# Altium schematic sheet Peripherals.SchDoc
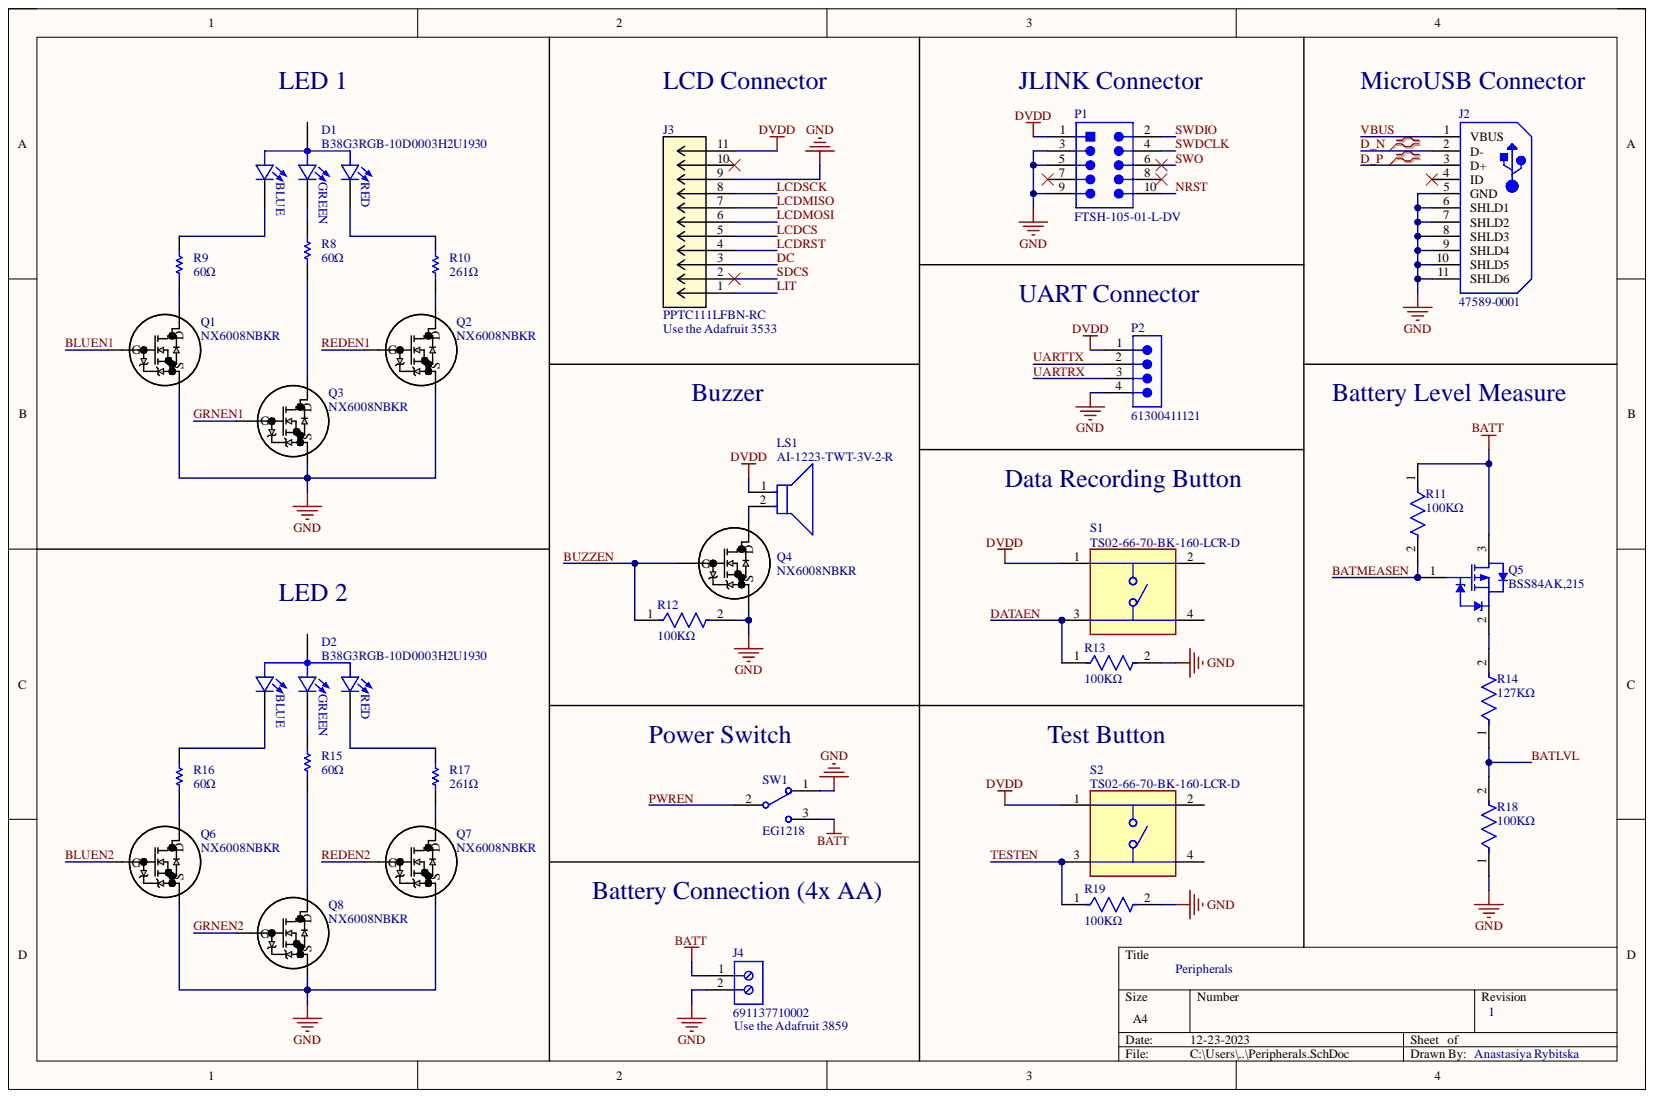
[diagram p0: Peripherals.SchDoc]

## Parts
D1  B38G3RGB-10D0003H2U1930  [LED_B38G3RGB-10D0003H2U1930]
D2  B38G3RGB-10D0003H2U1930  [LED_B38G3RGB-10D0003H2U1930]
J2  47589-0001  [MOLX-47589-0001_V]
J3  PPTC111LFBN-RC  [CONN11_PPPN111_SUL]
J4  691137710002  [691137710002]
LS1  AI-1223-TWT-3V-2-R  [FP-AI-1223-TWT-3V-2-R-MFG]
P1  FTSH-105-01-L-DV  [SMTC-FTSH-105-01-L-DV_V]
P2  61300411121  [61300411121]
Q1  NX6008NBKR  [NX6008NBKR_NEX]
Q2  NX6008NBKR  [NX6008NBKR_NEX]
Q3  NX6008NBKR  [NX6008NBKR_NEX]
Q4  NX6008NBKR  [NX6008NBKR_NEX]
Q5  BSS84AK,215  [NXP-SOT23_N]
Q6  NX6008NBKR  [NX6008NBKR_NEX]
Q7  NX6008NBKR  [NX6008NBKR_NEX]
Q8  NX6008NBKR  [NX6008NBKR_NEX]
R8  Resistance: 60Ω  [RESC1608X55X25LL10T15]  (hidden comment: RC0603JR-0762RL)
R9  Resistance: 60Ω  [RESC1608X55X25LL10T15]  (hidden comment: RC0603JR-0762RL)
R10  Resistance: 261Ω  [RESC1608X55X25LL10T15]  (hidden comment: RC0603FR-07261RL)
R11  Resistance: 100KΩ  [FP-RMCF0603-IPC_C]  (hidden comment: RMCF0603FT100K)
R12  Resistance: 100KΩ  [FP-RMCF0603-IPC_C]  (hidden comment: RMCF0603FT100K)
R13  Resistance: 100KΩ  [FP-RMCF0603-IPC_C]  (hidden comment: RMCF0603FT100K)
R14  value 127KΩ  Resistance: 127KΩ  [FP-RT0603-MFG]  (hidden comment: RT0603FRE07127KL)
R15  Resistance: 60Ω  [RESC1608X55X25LL10T15]  (hidden comment: RC0603JR-0762RL)
R16  Resistance: 60Ω  [RESC1608X55X25LL10T15]  (hidden comment: RC0603JR-0762RL)
R17  Resistance: 261Ω  [RESC1608X55X25LL10T15]  (hidden comment: RC0603FR-07261RL)
R18  Resistance: 100KΩ  [FP-RMCF0603-IPC_C]  (hidden comment: RMCF0603FT100K)
R19  Resistance: 100KΩ  [FP-RMCF0603-IPC_C]  (hidden comment: RMCF0603FT100K)
S1  TS02-66-70-BK-160-LCR-D  [SW_TS02-66-70-BK-160-LCR-D]
S2  TS02-66-70-BK-160-LCR-D  [SW_TS02-66-70-BK-160-LCR-D]
SW1  EG1218  [ESWI-EG1218_V]

## Nets
BATT: J4.1 Q5.3 R11.1 SW1.3
DVDD: J3.11 LS1.1 P1.1 P2.1 S1.1 S2.1
GND: J2.5(GND) J2.6(SHLD1) J2.7(SHLD2) J2.8(SHLD3) J2.9(SHLD4) J2.10(SHLD5) J2.11(SHLD6) J3.9 J4.2 P1.3 P1.5 P1.9 P2.4 Q1.2 Q2.2 Q3.2 Q4.2 Q6.2 Q7.2 Q8.2 R12.2 R13.2 R18.1 R19.2 SW1.1
BATLVL: R14.1 R18.2
BATMEASEN: Q5.1 R11.2
BLUEN1: Q1.1
BLUEN2: Q6.1
BUZZEN: Q4.1 R12.1
D_N: J2.2(D-)
D_P: J2.3(D+)
DATAEN: R13.1 S1.3
DC: J3.3
GRNEN1: Q3.1
GRNEN2: Q8.1
LCDCS: J3.5
LCDMISO: J3.7
LCDMOSI: J3.6
LCDRST: J3.4
LCDSCK: J3.8
LIT: J3.1
NRST: P1.10
PWREN: SW1.2
REDEN1: Q2.1
REDEN2: Q7.1
SDCS: J3.2
SWDCLK: P1.4
SWDIO: P1.2
SWO: P1.6
TESTEN: R19.1 S2.3
UARTRX: P2.3
UARTTX: P2.2
VBUS: J2.1(VBUS)
NetD1_1: D1.1 R8.2
NetD1_2: D1.2 R9.2
NetD1_4: D1.4 R10.2
NetD2_1: D2.1 R15.2
NetD2_2: D2.2 R16.2
NetD2_4: D2.4 R17.2
NetLS1_2: LS1.2 Q4.3
NetQ1_3: Q1.3 R9.1
NetQ2_3: Q2.3 R10.1
NetQ3_3: Q3.3 R8.1
NetQ5_2: Q5.2 R14.2
NetQ6_3: Q6.3 R16.1
NetQ7_3: Q7.3 R17.1
NetQ8_3: Q8.3 R15.1

Not connected: D1 3; D2 3; J2 4(ID); J3 10; P1 7 8; S1 2 4; S2 2 4

## Library data of the parts
D1 D2 = B38G3RGB-10D0003H2U1930: Red, Green, Blue (RGB) - LED Indication - Discrete 2V Red, 3V Green, 3V Blue 0606 (1616 Metric); MF: Harvatek Corporation; Availability: In Stock; Check_prices: https://www.snapeda.com/parts/B38G3RGB-10D0003H2U1930/Harvatek/view-part/?ref=eda; Component Kind: Standard; Library Name: Schlib1.SchLib; MP: B38G3RGB-10D0003H2U1930; Package: None; Price: None; Purchase-URL: https://pricing.snapeda.com/search/part/B38G3RGB-10D0003H2U1930/?ref=eda; SnapEDA_Link: https://www.snapeda.com/parts/B38G3RGB-10D0003H2U1930/Harvatek/view-part/?ref=snap
J2 = CMP-2000-05610-1: Right Angle Female Type AB Micro USB Connector, 30 V, 1.8 A, -30 to 85 degC, RoHS, Tape and Reel; Brand: Molex; Connector Type: MicroUSBTypeABreceptacle; Current Rating: 1.8A; Number of Contacts: 5Contact; Number of Ports: 1Port; Voltage Rating: 30VAC; Color: Black; Component Kind: Standard; Contact Material: CopperAlloy; Contact Plating: Gold; Datasheet URL: http://www.molex.com/webdocs/datasheets/pdf/en-us/0475890001_IO_CONNECTORS.pdf; Datasheet Version: 12/2016; Gender: Female; Library Name: Altium Content Vault; Manufacturer: Molex; Manufacturer URL: http://www.molex.com/; Mounting Angle: Right; Mounting Technology: SurfaceMount; Operating Temperature Range: -20Cto+85C; Package Description: Micro USB Connector, Pitch 0.65 mm, 5 Positions, Body 7.5 x 5.6 mm; Package Reference: 47589-0001; Package URL: http://www.molex.com/pdm_docs/sd/475890001_sd.pdf; Packaging: Reel; Product: MicroUSBTypeABConnectors; Product Category: USBConnectors; RoHS: True; Series: 47589; Standard: USB; Termination Style: SMD/SMT
J3 = PPTC111LFBN-RC: No Description Available; Mfr_Name: Sullins; Component Kind: Standard; Library Name: Schlib1.SchLib; Manufacturer_Part_Number: PPTC111LFBN-RC; RefDes: RefDes; Type: DEV; Copyright: Copyright (C) 2023 Ultra Librarian. All rights reserved.
J4 = CMP-1502-03324-2: NexarPartId: 78871135; Current Rating: 16A; Number of Contacts: 2; Voltage Rating: 300V; Component Kind: Standard; Contact Material: Nickel, Tin; Height: 11.6mm; Lead Pitch: 5mm; Library Name: Altium Content Vault; Max Operating Temperature: 120°C; Min Operating Temperature: -30°C; Number of Pins: 2; Number of Rows: 1; Packaging: Box; RoHS: Non-Compliant
LS1 = CMP-103888-000003-1: BUZZER MAGNETIC 3V 12MM TH; Frequency: 2.3kHz; Input Type: DC; Max Supply Current: 30mA; Max Supply Voltage (DC): 4V; Min Supply Voltage (DC): 2V; Component Kind: Standard; Contact Plating: Tin; Diameter: 12mm; Height: 7.5mm; Library Name: Altium Content Vault; Max Operating Temperature: 70°C; Min Operating Temperature: -20°C; Mount: Through Hole; Nominal Supply Voltage (DC): 3V; Operating Supply Current: 30mA; Packaging: Tray; Sensing Method: Magnetic; Series: AI; Termination: PC Pins; Voltage Rating: 3V
P1 = CMP-2000-05354-1: CONN HEADER SMD 10POS 1.27MM (get from Garmin, or order cheaper); Manufacturer: Samtec; Catalog Drawings: FTSHSeriesFTSHSeriesSMTSide; Connector Type: Header,Unshrouded; EDA / CAD Models: DownloadfromAcceleratedDesigns; Featured Product: Board-To-BoardInterconnectSystems; Mating Products: FLE-105-01-G-DV-TR-ND-CONNSKT1.27MM10POSDUALSMDCLP-105-02-G-D-P-TR-ND-CONNRCPT10POSDUAL.05"SMDSFMC-105-L2-S-D-TR-ND-CONN.05"SURFACE10POSSMTSFMH-105-02-L-D-TR-ND-CONNRECEPT10POS.50"SMTFLE-105-01-G-DV-A-ND-CONNSKT1.27MM10POSDUALSMDCLP-105-02-G-D-BE-ND-CONNRCPT10POSDUAL.05"SMDFLE-105-01-G-DV-A-P-ND-CONNSKT1.27MM10POSDUALSMDSAM1153-05-ND-CONNRCPT10POSDUAL.05"SMDSAM1155-05-ND-CONNRCPT10POSDUAL.05"SMDSAM1154-05-ND-CONNRCPT10POSDUAL.05"SMDSFMH-105-02-L-D-LC-ND-CONNRECEPT10POS.50"SMTSAM1226-05-ND-CONNRCPT.05"10POSDUALSMD; Number of Positions: 10; Number of Positions Loaded: All; Online Catalog: FTSHSeries; Other Names: SAM1161-05; Pitch: 0.050"(1.27mm); Product Training Modules: Board-to-BoardConnectors; Category: Connectors,Interconnects; Color: Black; Component Kind: Standard; Contact Finish: Gold; Contact Finish Thickness: 10µin(0.25µm); Contact Mating Length: 0.120"(3.05mm); Contact Type: MalePin; Datasheet URL: https://datasheet.ciiva.com/30493/0900766b80adfe36-30493006.pdf; Datasheet Version: Feb-08; Family: RectangularConnectors-Headers,MalePins; Fastening Type: -; Features: -; Library Name: Altium Content Vault; Manufacturer URL: http://www.samtec.com/; Mounting Type: SurfaceMount; Number of Rows: 2; Packaging: Bulk; Row Spacing: 0.050"(1.27mm); Series: FTSH; Standard Package: 1; Termination: Solder
P2 = CMP-1502-01064-2: NexarPartId: 32855522; Connector Type: Male Pin; Contact Resistance: 20mΩ; Current Rating: 3A; Gender: Male; Number of Contacts: 4; Operating Supply Voltage: 250V; Pitch: 2.54mm; Color: Black; Component Kind: Standard; Contact Material: Copper; Contact Mating Length: 5.9944mm; Contact Plating: Gold; Height: 8.89mm; Lead Free: Lead Free; Lead Pitch: 2.54mm; Library Name: Altium Content Vault; Max Operating Temperature: 105°C; Min Operating Temperature: -40°C; Mount: Through Hole; Number of Pins: 4; Number of Positions: 4; Number of Rows: 1; Orientation: Vertical; Packaging: Bulk; REACH SVHC: Unknown; RoHS: Compliant; Termination: Solder; Voltage Rating: 250V
Q1-Q4 Q6-Q8 = NX6008NBKR: No Description Available; Mfr_Name: Nexperia; Type: DEV; Component Kind: Standard; Library Name: Schlib1.SchLib; Manufacturer_Part_Number: NX6008NBKR; RefDes: RefDes; Copyright: Copyright (C) 2023 Ultra Librarian. All rights reserved.
Q5 = CMP-1259-00086-1: P-Channel Trench MOSFET, -50 V, -0.18 A, -55 to 150 degC, 3-Pin SOT23, Tape and Reel; Manufacturer: NXP Semiconductors; Automotive Qualified: Y; Channel Type: P; IDM [max] (A): -0.7; Packing: Tape & Reel; VGSth [typ] (V): -1.6; Code_JEDEC: TO-236AB; Component Kind: Standard; ComponentLink1Description: Manufacturer URL; ComponentLink1URL: http://www.nxp.com/; ComponentLink2Description: Datasheet; ComponentLink2URL: http://www.nxp.com/documents/data_sheet/BSS84AK.pdf; ComponentLink3Description: Package Specification; ComponentLink3URL: http://www.nxp.com/documents/outline_drawing/sot023_po.pdf; DatasheetVersion: Rev. 1; ID [max] (A): -0.18; Library Name: Altium Content Vault; Mounting Technology: Surface Mount; PackageDescription: 3-Pin Small Outline Transistor Package, Body 2.9 x 1.3 mm, Pitch 0.95 mm; PackageReference: SOT23; PackageVersion: 06-03-16, 03/2006; PartNumber: BSS84AK,215; VDS [max] (V): -50
R8 R9 R15 R16 = CMP-2000-04286-1: Manufacturer: Yageo; Component Kind: Standard; Library Name: Altium Content Vault; Part Number: RC0603JR-0762RL
R10 R17 = CMP-2000-03972-1: Manufacturer: Yageo; Component Kind: Standard; Library Name: Altium Content Vault; Part Number: RC0603FR-07261RL
R11-R13 R18 R19 = CMP-2000-06989-2: 100kΩ ±1% 0.1W 0603 Thick Film Chip Resistor AEC-Q200 compliant; Max Power Dissipation: 100mW; Temperature Coefficient: 100ppm/°C; Working Voltage: 75V; Case Code (Imperial): 0603; Case Code (Metric): 1608; Case/Package: 0603; Component Kind: Standard; Construction: Rectangular; Contact Plating: Tin; Depth: 0.8mm; Features: Automotive AEC-Q200; Height: 0.022inch; Lead Free: Lead Free; Length: 1.55mm; Library Name: Altium Content Vault; Max Operating Temperature: 155°C; Military Standard: Not; Min Operating Temperature: -55°C; Mount: Surface Mount; Number of Terminations: 2; Packaging: Tape and Reel; Power Rating: 100mW; Radiation Hardening: No; Resistor Type: Thick Film; RoHS Compliant: Yes; Series: RMCF; Tolerance: 1%; Width: 0.031inch
R14 = CMP-03412-001424-1: RES SMD 127K OHM 1% 1/10W 0603; Manufacturer: Yageo; Power Rating: 0.1W; Temperature Coefficient: 50 ppm/°C; Tolerance: 0.01; Voltage Rating: 75V; Automotive: No; Category: Res; Component Kind: Standard; ComponentLink2Description: Datasheet; ComponentLink2URL: http://www.yageo.com/documents/recent/PYu-RT_1-to-0.01_RoHS_L_9.pdf; Composition: Thin Film; Device Class L1: Passive Components; Device Class L2: Resistors; Device Class L3: Chip SMD Resistors; Digikey Description: RES SMD 127K OHM 1% 1/10W 0603; Footprint Url: http://www.yageo.com/exep/pages/download/literatures/PYu-R_Mount_10.pdf; Height: 0.55mm; Ipc Land Pattern Name: RESC16080X45; Lead Free: Yes; Library Name: Altium Content Vault; Manufacturer Part Number: RT0603FRE07127KL; Mouser Description: Thin Film Resistors - SMD 1/10W 127K ohm 1% 50ppm; Octopart: https://octopart.com/rt0603fre07127kl-yageo-1402897; Package: RT0603; RoHS: TRUE; Series: RT; Temperature: -55°C to +155°C; Temperature Range High: +155°C; Temperature Range Low: -55°C
S1 S2 = TS02-66-70-BK-160-LCR-D: 6 x 6 mm, 7 mm Actuator Height, 160 gf, Black, Long Crimped, Through Hole, SPST, Tactile Switch; MF: CUI Devices; Availability: In Stock; Check_prices: https://www.snapeda.com/parts/TS02-66-70-BK-160-LCR-D/CUI+Devices/view-part/?ref=eda; Component Kind: Standard; CUI_purchase_URL: https://www.cuidevices.com/product/switches/tactile-switches/ts02-series?utm_source=snapeda.com&utm_medium=referral&utm_campaign=snapedaBOM; Library Name: Schlib1.SchLib; MP: TS02-66-70-BK-160-LCR-D; Package: None; Price: None; Purchase-URL: https://pricing.snapeda.com/search/part/TS02-66-70-BK-160-LCR-D/?ref=eda; SnapEDA_Link: https://www.snapeda.com/parts/TS02-66-70-BK-160-LCR-D/CUI+Devices/view-part/?ref=snap
SW1 = CMP-1696-00002-1: Slide Switch, 500 V, -20 to 70 degC, 3-Pin THD, RoHS; Case\Package: SOT-23-5; Dropout Voltage: 300 mV; Max Input Voltage: 8 V; Max Ouput Current: 150 mA; Max Power Dissipation: 250 mW; Min Input Voltage: 4.3 V; Nominal Output Voltage: 3.3 V; Outputs: 1; Component Kind: Standard; ComponentLink1Description: Manufacturer URL; ComponentLink1URL: http://www.e-switch.ch/en/; ComponentLink2Description: Datasheet; ComponentLink2URL: https://www.e-switch.com/system/asset/product_line/data_sheet/119/EG.pdf; DatasheetVersion: Oct-15; Height: 1.3 mm; Length: 3 mm; Library Name: Altium Content Vault; Max Operating Temperature: 85 degC; Min Operating Temperature: -40 degC; Mount: Surface Mount; Output Current: 150 mA; Output Voltage: 3.3 V; Package Quantity: 3000; PackageDescription: 3-Pin Through Hole Device, Body 11.6 x 4 mm, Pitch 2.5 mm; PackageReference: EG1218; Packaging: Tape and Reel; Pins: 5; Power Rating: 250 mW; Radiation Hardening: No; REACH SVHC: No SVHC; RoHSCompliant: true; Width: 1.8 mm
